# Altium schematic sheet SCH_Reg.SchDoc(SCH_Reg)
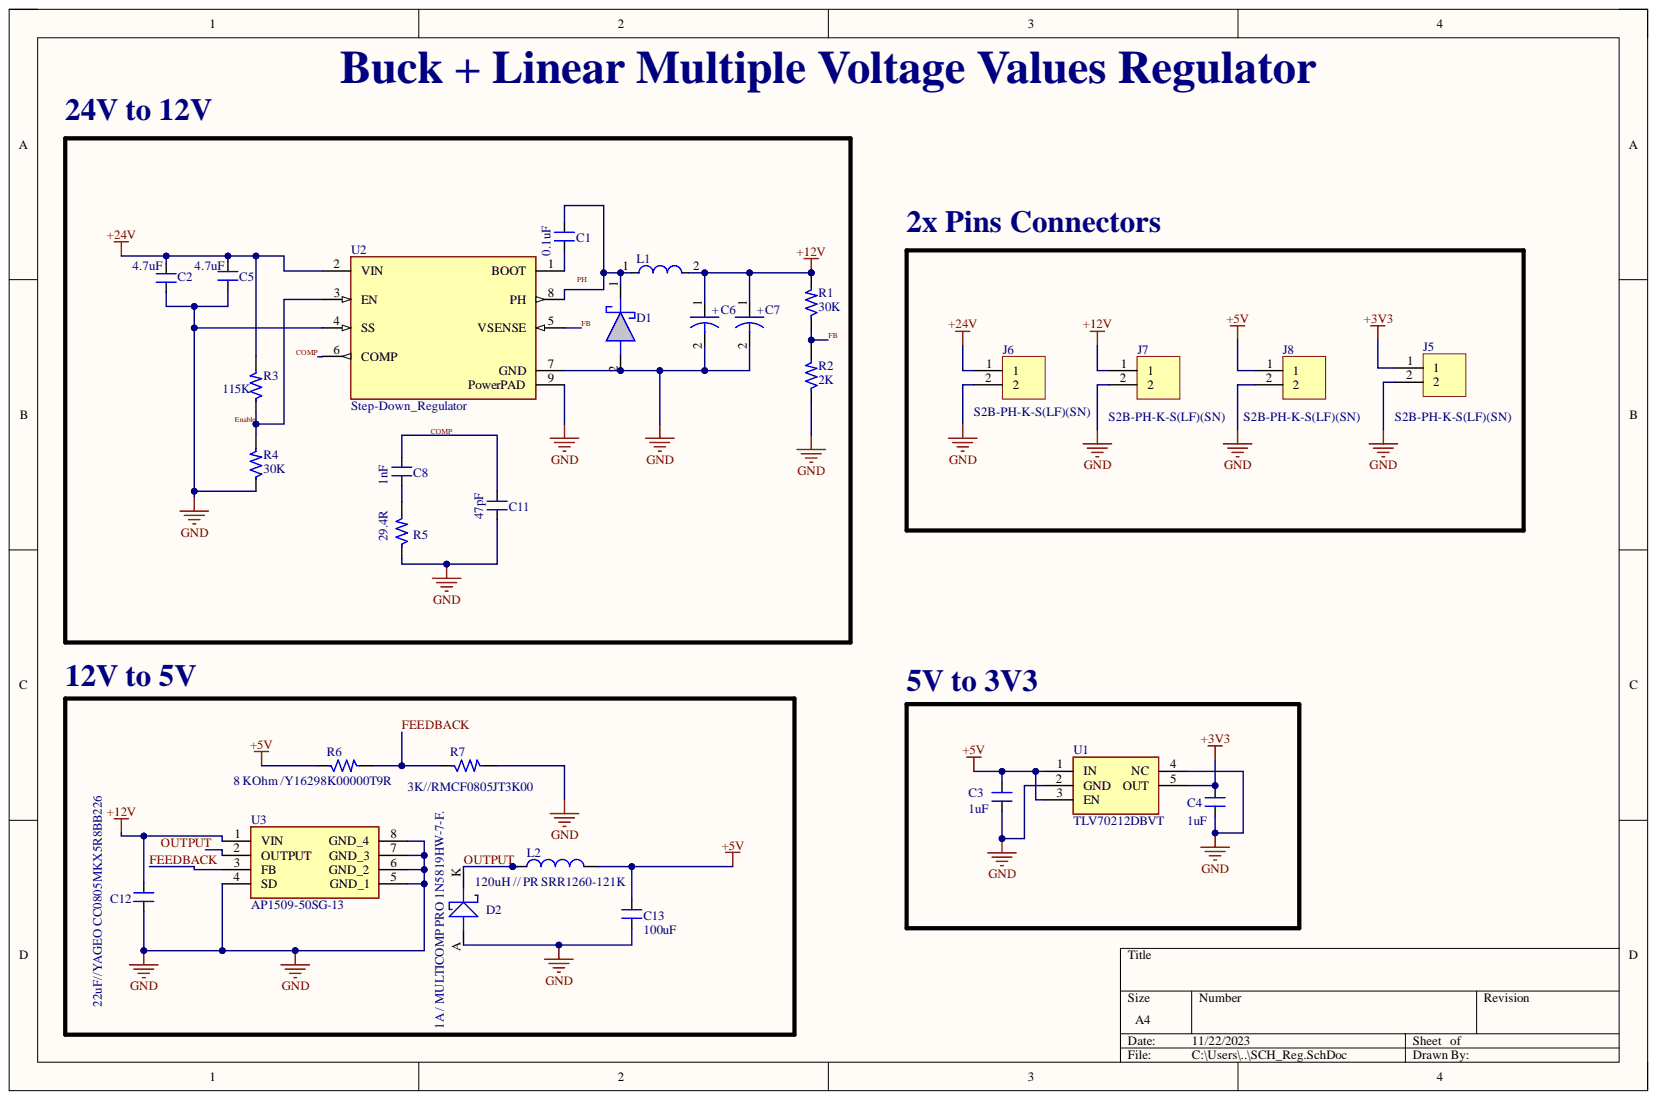
[diagram p0: SCH_Reg.SchDoc]

## Parts
C1  0.1uF  [CAP_0805]
C2  4.7uF  [CAP_0805]
C3  1uF  [CAP_0805]
C4  1uF  [CAP_0805]
C5  4.7uF  [CAP_0805]
C6  47uF//Manufacturer Part No. EEEFT1V470AR  [EEEFT1V470AR]  (value not drawn)
C7  47uF//Manufacturer Part No. EEEFT1V470AR  [EEEFT1V470AR]  (value not drawn)
C8  1nF  [CAP_0805]
C11  47pF  [CAP_0805]
C12  22uF//YAGEO CC0805MKX5R8BB226  [CAP_0805]
C13  100uF  [CAP_0805]
D1  B240Q-13-F  [B240Q-13-F]  (value not drawn)
D2  1A / MULTICOMP PRO 1N5819HW-7-F.  [DIO_SOD-123]
J5  S2B-PH-K-S(LF)(SN)  [CON2_78_S2B-PH-K-S_TH_RA]
J6  S2B-PH-K-S(LF)(SN)  [CON2_78_S2B-PH-K-S_TH_RA]
J7  S2B-PH-K-S(LF)(SN)  [CON2_78_S2B-PH-K-S_TH_RA]
J8  S2B-PH-K-S(LF)(SN)  [CON2_78_S2B-PH-K-S_TH_RA]
L1  SSR1260-330M  [IND_33uH_60m_Ohm_3A]  (value not drawn)
L2  120uH // PR SRR1260-121K  [IND_456_640_236X117_SMD]
R1  30K  [RES_0805]
R2  2K  [RES_0805]
R3  115K  [RES_0805]
R4  30K  [RES_0805]
R5  29.4R  [RES_0805]
R6  8 KOhm /Y16298K00000T9R  [RES_0805]
R7  3K//RMCF0805JT3K00  [RES_0805]
U1  TLV70212DBVT  [SOT95P280X145-5N-LDO5v-3v3]
U2  Step-Down_Regulator  [TPS54331DDA]
U3  AP1509-50SG-13  [SOIC127P600X175-8N]

## Nets
+3V3: C4.2 J5.1 U1.5(OUT)
+5V: C3.2 C13.2 J8.1 L2.2 R6.1 U1.1(IN) U1.3(EN)
+12V: C6.1 C7.1 C12.2 J7.1 L1.2 R1.2 U3.1(VIN)
+24V: C2.2 C5.2 J6.1 R3.2 U2.2(VIN)
GND: C2.1 C3.1 C4.1 C5.1 C6.2 C7.2 C11.1 C12.1 C13.1 D1.2 D2.A J5.2 J6.2 J7.2 J8.2 R2.1 R4.1 R5.1 R7.2 U1.2(GND) U1.4(NC) U2.4(SS) U2.7(GND) U2.9(PowerPAD) U3.4(SD) U3.5(GND_1) U3.6(GND_2) U3.7(GND_3) U3.8(GND_4)
COMP: C8.2 C11.2 U2.6(COMP)
Enable: R3.1 R4.2 U2.3(EN)
FB: R1.1 R2.2 U2.5(VSENSE)
FEEDBACK: R6.2 R7.1 U3.3(FB)
OUTPUT: D2.K L2.1 U3.2(OUTPUT)
NetC1_1: C1.1 U2.1(BOOT)
NetC1_2: C1.2 D1.1 L1.1 U2.8(PH)
NetC8_1: C8.1 R5.2
PH:

## Library data of the parts
C1 = CAP_150pF-50V-10%-0805: 150pF ±10% 50V Ceramic Capacitor C0G, NP0 0805 (2012 Metric); Alternate Part Number-1: NA; Manufacturer: AVX Corporation; Manufacturer Part Number: 08055A151KAT2A; Case/Package: 0805; Component Kind: Standard; Supplier Part Number-1: 478-10501-1-ND - Cut Tape (CT); Supplier-1: Digikey; Library Name: NOUMANPCBLIB.IntLib
C2 C5 = CAP_4.7uF-50V-10%-0805: 4.7µF ±10% 50V Ceramic Capacitor X5R 0805 (2012 Metric); Alternate Part Number-1: NA; Manufacturer: Yageo; Manufacturer Part Number: GRM21BR61H475KE51L; Case/Package: 0805; Component Kind: Standard; Supplier Part Number-1: CL21A475KBQNNNE; Supplier-1: SIRICOM; Library Name: NOUMANPCBLIB.IntLib
C3 C4 = CAP_1uF-50V-10%-0805_1: 1µF ±10% 50V Ceramic Capacitor X7R 0805 (2012 Metric); Alternate Part Number-1: NA; Manufacturer: Samsung; Manufacturer Part Number: CL21B104KBCNNNC; Case/Package: 0805; Component Kind: Standard; Supplier Part Number-1: 0805B104K500CT; Supplier-1: SIRICOM; Library Name: NOUMANPCBLIB.IntLib
C6 C7 = CAP-P_47uF-35V-20%-RAD: 47µF 35V Aluminum Electrolytic Capacitors Radial, Can - SMD 1000 Hrs @ 85°C//or Aluminum Electrolytic Capacitor, 47 uF, 35 V, ± 20%, 2000 hours @ 105°C, Radial Can - SMD. I Added a new footprint, the previous one which is not exist was ACAP_P180_D259_H212_RAD; Alternate Part Number-1: NA; Manufacturer: Panasonic Electronic Components; Manufacturer Part Number: EEE-1VA470WP; Case/Package: Radial-SMD; Component Kind: Standard; Supplier Part Number-1: RV5-35V470MF55U-R; Supplier-1: SIRICOM; Library Name: NOUMANPCBLIB.IntLib
C8 C11 = CAP_47pF-50V-5%-0805: 47pF ±5% 50V Ceramic Capacitor C0G, NP0 0805 (2012 Metric); Alternate Part Number-1: NA; Manufacturer: KEMET; Manufacturer Part Number: C0805C470J5GACTU; Case/Package: 0805; Component Kind: Standard; Supplier Part Number-1: 0805N450J500CT; Supplier-1: SIRICOM; Library Name: NOUMANPCBLIB.IntLib
C12 = CAP_0.22uF-50V-10%-0805: 0.22µF ±10% 50V Ceramic Capacitor X7R 0805 (2012 Metric); Alternate Part Number-1: 0805F224M500NT; Manufacturer: Murata; Manufacturer Part Number: GRM21BR71H224KA01L; Case/Package: 0805; Component Kind: Standard; Supplier Part Number-1: 0805B224K500CT; Supplier-1: SIRICOM; Library Name: NOUMANPCBLIB.IntLib
C13 = CAP_100uF-50V-10%-0805: 100µF ±10% 50V Ceramic Capacitor X7R 0805 (2012 Metric); Alternate Part Number-1: NA; Manufacturer: Samsung; Manufacturer Part Number: CL21B104KBCNNNC; Case/Package: 0805; Component Kind: Standard; Supplier Part Number-1: 0805B104K500CT; Supplier-1: SIRICOM; Library Name: NOUMANPCBLIB.IntLib
D1 = DIO_40V_2A_SMD: Diode 40 V 2A Surface Mount SMB,DIODE SCHOTTKY 40V 2A SMB.; Component Kind: Standard; Library Name: NOUMANPCBLIB.IntLib
D2 = DIO-S_0.6V-40V-0.5A-SOD-123: Schottky Diodes & Rectifiers 0.5A 40V; Alternate Part Number-1: NA; Manufacturer: ON Semiconductor; Manufacturer Part Number: MBR0540T1G; Case/Package: SOD-123; Component Kind: Standard; Supplier Part Number-1: 863-MBR0540T1G; Supplier-1: Mouser; Library Name: NOUMANPCBLIB.IntLib
J5-J8 = CON-PLG_2X1-78-RA-TH: 2 Pins, 1 Row 2mm Pitch, Right angle Connector, male; Alternate Part Number-1: NA; Crimp Part Number: ASPHSPH24K51; Manufacturer: JST; Mating Part Number: PHR-2; Case/Package: TH-CON; Component Kind: Standard; Manufacturer Part Number: S2B-PH-K-S(LF)(SN); Supplier Part Number-1: S2B-PH-K-S(LF)(SN); Supplier-1: ARROW; Library Name: NOUMANPCBLIB.IntLib
L1 = IND_33uH_60m_Ohm_3A: IND FIXED IND 33UH 3A 60 MOHM SMD; Component Kind: Standard; Library Name: NOUMANPCBLIB.IntLib
L2 = IND_33uH-8A-30%-SMD: Fixed Inductors 0.33uH 20% 8A; Alternate Part Number-1: NA; Manufacturer: Coilcraft; Manufacturer Part Number: SRP3020TA-R33M; Case/Package: SMD; Component Kind: Standard; Supplier Part Number-1: SER2918H-333KL; Supplier-1: COILCRAFT SAMPLE; Library Name: NOUMANPCBLIB.IntLib
R1 R2 R4 = RES_1.25R-0.125W-1%-0805: Thick Film Resistors 1/8watt 1.24ohms 1%; Alternate Part Number-1: NA; Manufacturer: Vishay / Dale; Manufacturer Part Number: CRCW08051R24FKEA; Case/Package: 0805; Component Kind: Standard; Supplier Part Number-1: NA; Supplier-1: SIRICOM; Library Name: NOUMANPCBLIB.IntLib
R3 R5 = RES_0R-0.125W-1%-0805: 0 Ohms Jumper 0.125W, 1/8W Chip Resistor 0805 (2012 Metric) Automotive AEC-Q200 Thick Film; Alternate Part Number-1: WR08X000PTL; Manufacturer: Walsin; Case/Package: 0805; Component Kind: Standard; Manufacturer Part Number: WR08X000PTL; Supplier Part Number-1: WR08X000PTL; Supplier-1: SIRICOM; Library Name: NOUMANPCBLIB.IntLib
R6 R7 = RES_1K-0.125W-1%-0805: 1 kOhms ±1% 0.125W, 1/8W Chip Resistor 0805 (2012 Metric) Moisture Resistant Thick Film; Alternate Part Number-1: WR08X1001FTL; Manufacturer: Walsin; Case/Package: 0805; Component Kind: Standard; Manufacturer Part Number: WR08X1001FTL; Supplier Part Number-1: RC0805JR-071KL; Supplier-1: SP ROAD; Library Name: NOUMANPCBLIB.IntLib
U1 = REG_LDO_5.5V_3.3V_300mA: Texas Instruments TLV70212DBVT, Low Noise LDO Voltage Regulator, 300mA, 1.2  4.8 V 2%, 5-Pin SOT-23; Component Kind: Standard; Library Name: NOUMANPCBLIB.SchLib
U2 = REG_28v_to_25v_with_3A,570KHZ: The TPS54331 device is a 28-V, 3-A with 570Khz.; Component Kind: Standard; Library Name: NOUMANPCBLIB.IntLib
U3 = REG-Switching Voltage Regulators SW REG CNV BUCK ASYN 150K 1.4 22V 5.0V: DiodesZetex, AP1509-50SG-13 DC-DC Converter 2A 8-Pin, SOP, 150KHz, 2A PWM BUCK DC/DC CONVERTER, Efficiency 83%.; Component Kind: Standard; Library Name: NOUMANPCBLIB.IntLib
